# Altium schematic sheet lc4_decoder.SchDoc
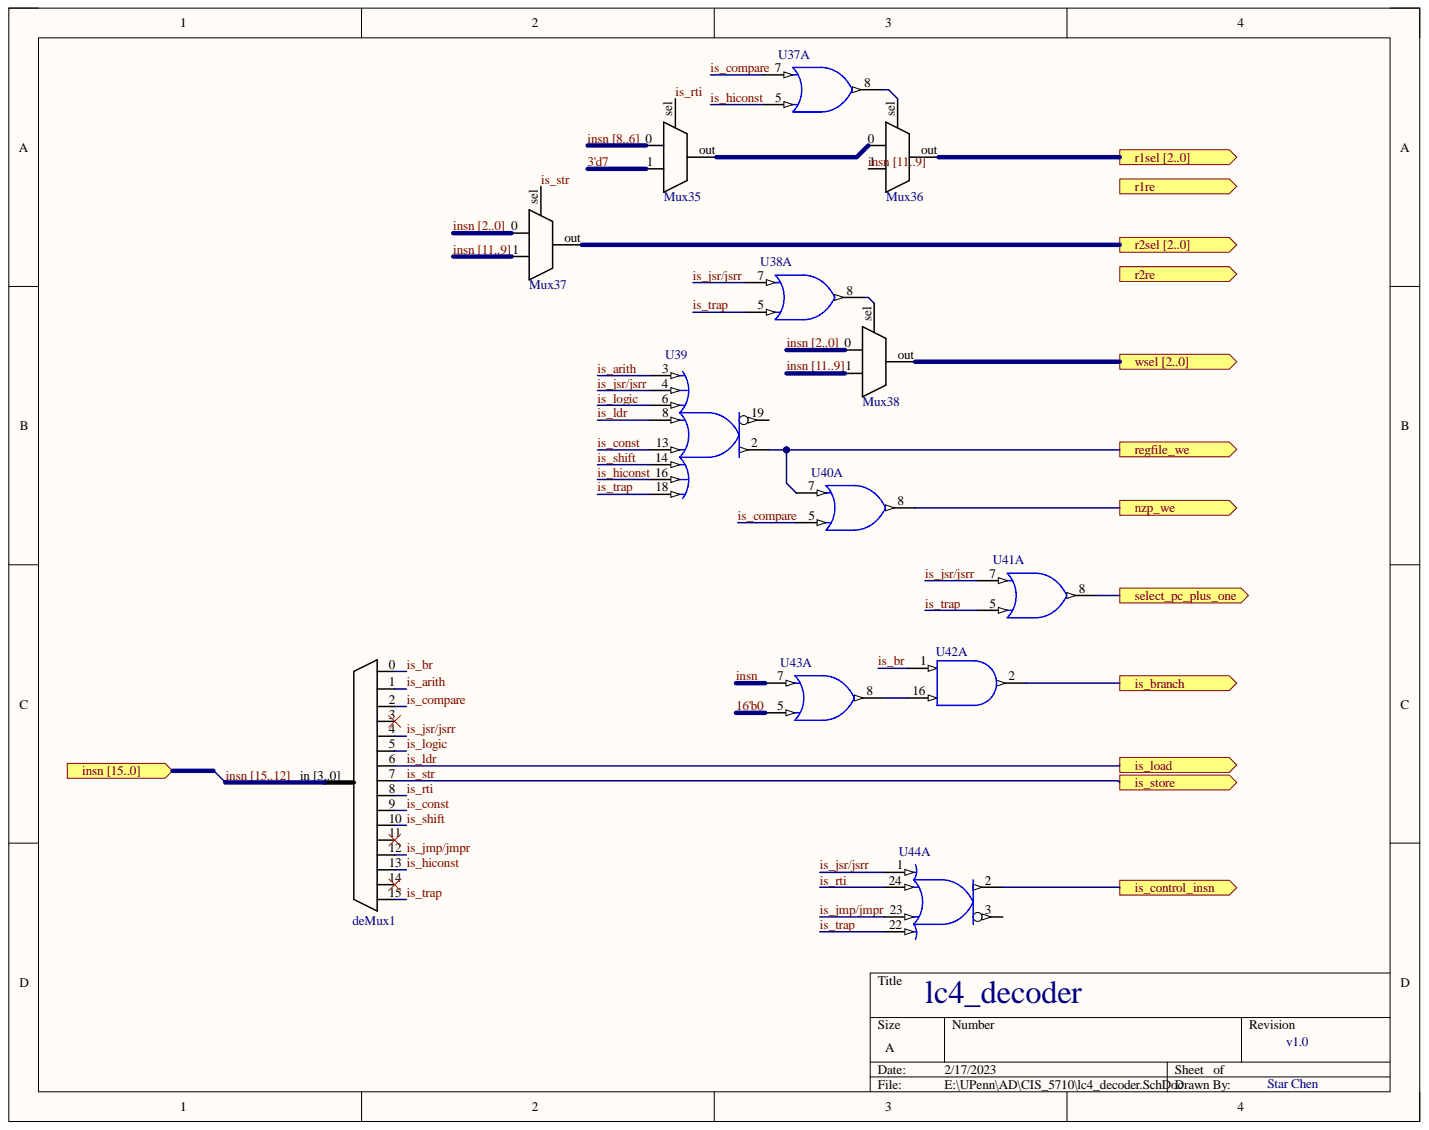
[diagram p0: lc4_decoder.SchDoc]

## Parts
deMux1  1-to-16 deMux  (value not drawn)
Mux35  Mux
Mux36  Mux
Mux37  Mux
Mux38  Mux
U37  54AC11032FK  [FK020D]  (value not drawn)  (part A)
U38  54AC11032FK  [FK020D]  (value not drawn)  (part A)
U39  SN54HC4078AFK  [FK020]  (value not drawn)
U40  54AC11032FK  [FK020D]  (value not drawn)  (part A)
U41  54AC11032FK  [FK020D]  (value not drawn)  (part A)
U42  54AC11008J  [J016D]  (value not drawn)  (part A)
U43  54AC11032FK  [FK020D]  (value not drawn)  (part A)
U44  54ACT11802JT  [JT024C]  (value not drawn)  (part A)

## Ports
insn 0, insn 1, insn 2, insn 3, insn 4, insn 5, insn 6, insn 7, insn 8, insn 9, insn 10, insn 11, insn 12, insn 13, insn 14, insn 15, insn [15..0], is_branch, is_control_insn, is_load, is_store, nzp_we, r1re, r1sel [2..0], r2re, r2sel 0, r2sel 1, r2sel 2, r2sel [2..0], regfile_we, select_pc_plus_one, wsel 0, wsel 1, wsel 2, wsel [2..0]

## Nets
GND: U37.10 U37.12 U38.10 U38.12 U39.10 U40.10 U40.12 U41.10 U41.12 U42.4 U42.5 U43.10 U43.12 U44.5 U44.6 U44.7 U44.8
VCC: U37.2 U37.20 U38.2 U38.20 U39.20 U40.2 U40.20 U41.2 U41.20 U42.12 U42.13 U43.2 U43.20 U44.18 U44.19
3'd7: Mux35.1
16'b0: U43.5
insn: U43.7
insn [2..0]: Mux37.0 Mux38.0
insn [8..6]: Mux35.0
insn [11..9]: Mux36.1 Mux37.1 Mux38.1
is_arith: deMux1.1 U39.3
is_br: deMux1.0 U42.1
is_branch: U42.2 ; port is_branch
is_compare: deMux1.2 U37.7 U40.5
is_const: deMux1.9 U39.13
is_control_insn: U44.2 ; port is_control_insn
is_hiconst: deMux1.13 U37.5 U39.16
is_jmp/jmpr: deMux1.12 U44.23
is_jsr/jsrr: deMux1.4 U38.7 U39.4 U41.7 U44.1
is_ldr: deMux1.6 U39.8 ; port is_load
is_logic: deMux1.5 U39.6
is_rti: deMux1.8 Mux35.sel U44.24
is_shift: deMux1.10 U39.14
is_str: deMux1.7 Mux37.sel ; port is_store
is_trap: deMux1.15 U38.5 U39.18 U41.5 U44.22
nzp_we: U40.8 ; port nzp_we
regfile_we: U39.2 U40.7 ; port regfile_we
select_pc_plus_one: U41.8 ; port select_pc_plus_one
NetMux35_out: Mux35.out Mux36.0
NetMux36_sel: Mux36.sel U37.8
NetMux38_sel: Mux38.sel U38.8
NetU42_16: U42.16 U43.8
insn 12:  [also labelled insn]
insn 13:  [also labelled insn]
insn 14:  [also labelled insn]
insn 15:  [also labelled insn]
N00001_0: ; port wsel [2..0]
N00001_1: ; port wsel [2..0]
N00001_2: ; port wsel [2..0]
N00002_0: ; port insn [15..0]
N00002_1: ; port insn [15..0]
N00002_2: ; port insn [15..0]
N00002_3: ; port insn [15..0]
N00002_4: ; port insn [15..0]
N00002_5: ; port insn [15..0]
N00002_6: ; port insn [15..0]
N00002_7: ; port insn [15..0]
N00002_8: ; port insn [15..0]
N00002_9: ; port insn [15..0]
N00002_10: ; port insn [15..0]
N00002_11: ; port insn [15..0]
N00002_12: ; port insn [15..0]
N00002_13: ; port insn [15..0]
N00002_14: ; port insn [15..0]
N00002_15: ; port insn [15..0]
N00003_0: ; port r2sel [2..0]
N00003_1: ; port r2sel [2..0]
N00003_2: ; port r2sel [2..0]
N00004: ; port r1sel [2..0]
N00005: ; port r1re
N00006: ; port r2re

Not connected: deMux1 3 11 14 in [3..0]; Mux36 out(insn); Mux37 out; Mux38 out; U39 19; U44 3

## Library data of the parts
deMux1 = deMux_16: Component Kind: Standard; Library Name: Schlib1.SchLib
U37 U38 U40 U41 U43 = 54AC11032FK: Quadruple 2-Input Positive-OR Gate; Note: Pins 1, 6, 11 and 16 are not connected and not drawn; Component Kind: Standard; ComponentLink1Description: Manufacturer Link; ComponentLink1URL: http://www.ti.com/; ComponentLink2Description: Packaging Specification; ComponentLink2URL: http://www-s.ti.com/sc/psheets/mlcc006b/mlcc006b.pdf; DatasheetVersion: Mar-1990; LatestRevisionDate: 17-Jul-2002; LatestRevisionNote: Re-released for DXP Platform.; Library Name: TI Logic Gate 1.IntLib; PackageDescription: Leadless Chip Carrier; 20 Terminals; Body 8.9 x 8.9 mm; PackageReference: FK020D; PackageVersion: 1998; Published: 8-Jun-2000; Publisher: Altium Limited
U39 = SN54HC4078AFK: 8-Input OR / NOR Gate; Note: Pins 1, 5, 7, 9, 11, 12, 15 and 17 are not connected and not drawn; Component Kind: Standard; ComponentLink1Description: Manufacturer Link; ComponentLink1URL: http://www.ti.com/; ComponentLink2Description: Packaging Specification; ComponentLink2URL: http://www-s.ti.com/sc/psheets/mlcc006b/mlcc006b.pdf; DatasheetVersion: Sep-1987; LatestRevisionDate: 17-Jul-2002; LatestRevisionNote: Re-released for DXP Platform.; Library Name: TI Logic Gate 1.IntLib; PackageDescription: Leadless Chip Carrier; 20 Terminals; Body 8.9 x 8.9 mm; PackageReference: FK020; PackageVersion: 1998; Published: 8-Jun-2000; Publisher: Altium Limited
U42 = 54AC11008J: Quadruple 2-Input Positive-AND Gate; Component Kind: Standard; ComponentLink1Description: Manufacturer Link; ComponentLink1URL: http://www.ti.com/; ComponentLink2Description: Packaging Specification; ComponentLink2URL: http://focus.ti.com/lit/ml/mcer002d/mcer002d.pdf; DatasheetVersion: Mar-1990; LatestRevisionDate: 04-Mar-2005; LatestRevisionNote: Stylized 3D Model Added.; Library Name: TI Logic Gate 1.IntLib; PackageDescription: DIP; 16 Leads; Row Spacing 7.62 mm; Pitch 2.54 mm; PackageReference: J016D; PackageVersion: Jun-1999; PCB3D: J016; Published: 8-Jun-2000; Publisher: Altium Limited
U44 = 54ACT11802JT: Triple 4-Input OR / NOR Clock Driver; Code_JEDEC: MS-001-AF; Component Kind: Standard; ComponentLink1Description: Manufacturer Link; ComponentLink1URL: http://www.ti.com/; ComponentLink2Description: Packaging Specification; ComponentLink2URL: http://focus.ti.com/lit/ml/mcer004a/mcer004a.pdf; DatasheetVersion: Jan-1992; LatestRevisionDate: 04-Mar-2005; LatestRevisionNote: Stylized 3D Model Added.; Library Name: TI Logic Gate 1.IntLib; PackageDescription: DIP; 24 Leads; Row Spacing 7.62 mm; Pitch 2.54 mm; PackageReference: JT024C; PackageVersion: Jan-1997; PCB3D: JT024; Published: 8-Jun-2000; Publisher: Altium Limited
Mux35-Mux38 = Mux: Component Kind: Standard; Library Name: Schlib1.SchLib
